# Altium schematic sheet STM108Core.SchDoc
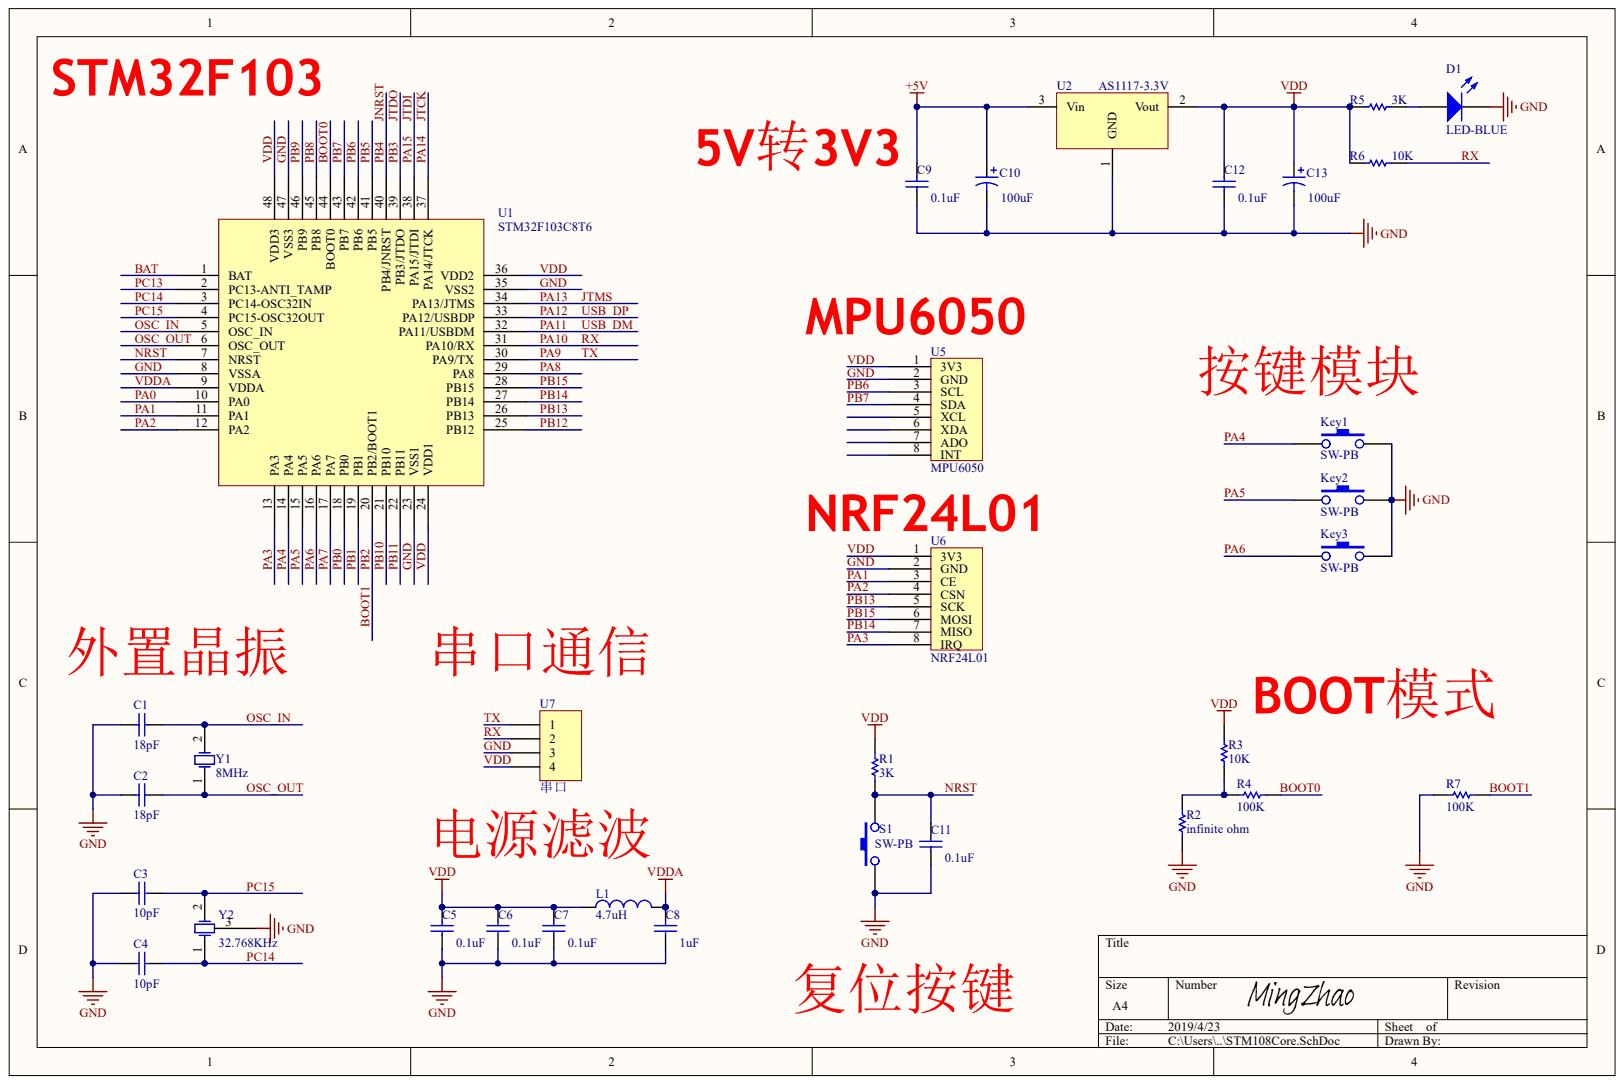
[diagram p0: STM108Core.SchDoc]

## Parts
C1  value 18pF  (hidden comment: Cap)
C2  value 18pF  (hidden comment: Cap)
C3  value 10pF  (hidden comment: Cap)
C4  value 10pF  (hidden comment: Cap)
C5  value 0.1uF  (hidden comment: Cap)
C6  value 0.1uF  (hidden comment: Cap)
C7  value 0.1uF  (hidden comment: Cap)
C8  value 1uF  (hidden comment: Cap)
C9  value 0.1uF  (hidden comment: Cap)
C10  value 100uF  (hidden comment: Cap Pol2)
C11  value 0.1uF  (hidden comment: Cap)
C12  value 0.1uF  (hidden comment: Cap)
C13  value 100uF  (hidden comment: Cap Pol2)
D1  LED-BLUE
Key1  SW-PB
Key2  SW-PB
Key3  SW-PB
L1  value 4.7uH  (hidden comment: Inductor)
R1  value 3K  (hidden comment: Res1)
R2  value infinite ohm  (hidden comment: Res1)
R3  value 10K  (hidden comment: Res1)
R4  value 100K  (hidden comment: Res1)
R5  value 3K  (hidden comment: Res1)
R6  value 10K  (hidden comment: Res1)
R7  value 100K  (hidden comment: Res1)
S1  SW-PB
U1  STM32F103C8T6
U2  AS1117-3.3V
U5  MPU6050
U6  NRF24L01
U7  串口
Y1  8MHz
Y2  32.768KHz

## Nets
+5V: C9.2 C10.1 U2.3
BAT: U1.1
BOOT0: R4.1 U1.44
BOOT1: R7.1 U1.20
GND: C1.2 C2.2 C3.2 C4.2 C5.1 C6.1 C7.1 C8.1 C9.1 C10.2 C11.1 C12.1 C13.2 D1.2 Key1.2 Key2.2 Key3.2 R2.1 R7.2 S1.1 U1.8 U1.23 U1.35 U1.47 U2.1 U5.2 U6.2 U7.3 Y2.3
JNRST: U1.40
JTCK: U1.37
JTDI: U1.38
JTDO: U1.39
JTMS: U1.34
NRST: C11.2 R1.1 S1.2 U1.7
OSC_IN: C1.1 U1.5 Y1.2
OSC_OUT: C2.1 U1.6 Y1.1
PA0: U1.10
PA1: U1.11 U6.3
PA2: U1.12 U6.4
PA3: U1.13 U6.8
PA4: Key1.1 U1.14
PA5: Key2.1 U1.15
PA6: Key3.1 U1.16
PA7: U1.17
PA8: U1.29
PA9: U1.30 U7.1
PA10: R6.2 U1.31 U7.2
PA11: U1.32
PA12: U1.33
PB0: U1.18
PB1: U1.19
PB5: U1.41
PB6: U1.42 U5.3
PB7: U1.43 U5.4
PB8: U1.45
PB9: U1.46
PB10: U1.21
PB11: U1.22
PB12: U1.25
PB13: U1.26 U6.5
PB14: U1.27 U6.7
PB15: U1.28 U6.6
PC13: U1.2
PC14: C4.1 U1.3 Y2.1
PC15: C3.1 U1.4 Y2.2
VDD: C5.2 C6.2 C7.2 C12.2 C13.1 L1.1 R1.2 R3.2 R5.1 R6.1 U1.24 U1.36 U1.48 U2.2 U5.1 U6.1 U7.4
VDDA: C8.2 L1.2 U1.9
NetD1_1: D1.1 R5.2
NetR2_2: R2.2 R3.1 R4.2

Not connected: U5 5 6 7 8

## Library data of the parts
L1 = (no symbol name): Code_IEC: 1005; Published: 8-Jun-2000; LatestRevisionDate: 29-May-2009; LatestRevisionNote: IPC-7351 Footprint Added.; PackageReference: 0402-A; PackageDescription: Chip Inductor; Code_JEITA: 0402; Publisher: Altium Limited
R2 = (no symbol name): Value: infinite ohm; Published: 8-Jun-2000; LatestRevisionDate: 17-Jul-2002; LatestRevisionNote: Re-released for DXP Platform.; PackageReference: AXIAL-0.3; PackageDescription: Axial Device, Thru-Hole; 2 Leads; 0.3 in Pin Spacing; Publisher: Altium Limited
C1-C13 D1 Key1-Key3 R1 R3-R7 S1 U1 U2 U5-U7 Y1 Y2 = (no symbol name)
  C1-C9 C11 C12: Published: 8-Jun-2000; LatestRevisionDate: 17-Jul-2002; LatestRevisionNote: Re-released for DXP Platform.; PackageReference: RAD-0.3
  C10 C13: Published: 8-Jun-2000; LatestRevisionDate: 17-Jul-2002; LatestRevisionNote: Re-released for DXP Platform.; PackageReference: POLAR0.8
  D1: Published: 8-Jun-2000; LatestRevisionDate: 08-Jul-2005; LatestRevisionNote: Mech Layer 1 removed.; PackageReference: LED-0; PackageDescription: LED; 2 Leads
  R1 R3-R7: Published: 8-Jun-2000; LatestRevisionDate: 17-Jul-2002; LatestRevisionNote: Re-released for DXP Platform.; PackageReference: AXIAL-0.3; PackageDescription: Axial Device, Thru-Hole; 2 Leads; 0.3 in Pin Spacing
  U7: LatestRevisionDate: 17-Jul-2002; LatestRevisionNote: Re-released for DXP Platform.
  Y1 Y2: Published: 8-Jun-2000; LatestRevisionDate: 17-Jul-2002; LatestRevisionNote: Re-released for DXP Platform.; PackageReference: R38; PackageDescription: Crystal, Thru-Hole; 2 Leads; Body 3.1 x 8.2 mm (Dia.xH)
  C1-C13 D1 R1 R3-R7 U7 Y1 Y2: Publisher: Altium Limited
